# Altium schematic sheet Power.SchDoc(Power)
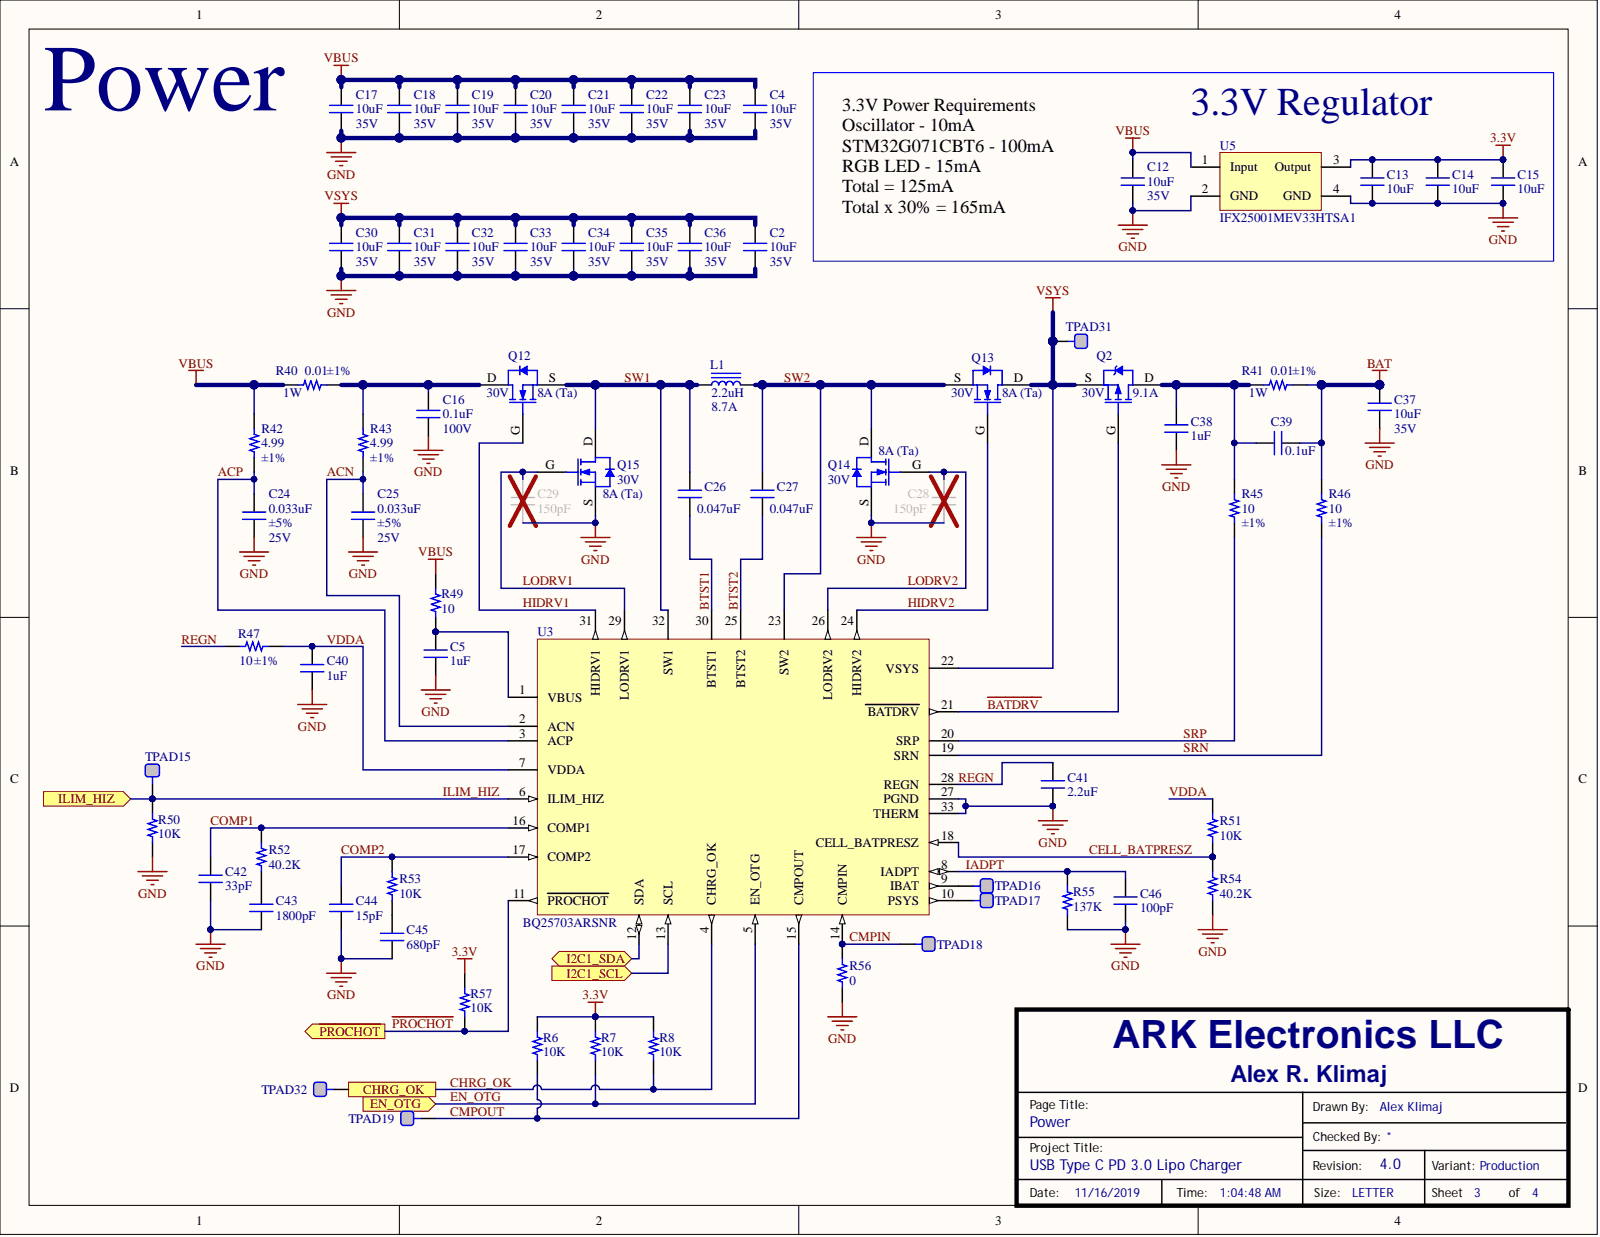
[diagram p0: Power.SchDoc]

## Parts
C2  10uF  Voltage_Rating: 35V  [CAPC0805(2012)155_N]
C4  10uF  Voltage_Rating: 35V  [CAPC0805(2012)155_N]
C5  1uF  [CAPC0805(2012)155_N]
C12  10uF  Voltage_Rating: 35V  [CAPC0805(2012)155_N]
C13  10uF  [CAPC0805(2012)155_N]
C14  10uF  [CAPC0805(2012)155_N]
C15  10uF  [CAPC0805(2012)155_N]
C16  0.1uF  Voltage_Rating: 100V  [CAPC0805(2012)155_N]
C17  10uF  Voltage_Rating: 35V  [CAPC0805(2012)155_N]
C18  10uF  Voltage_Rating: 35V  [CAPC0805(2012)155_N]
C19  10uF  Voltage_Rating: 35V  [CAPC0805(2012)155_N]
C20  10uF  Voltage_Rating: 35V  [CAPC0805(2012)155_N]
C21  10uF  Voltage_Rating: 35V  [CAPC0805(2012)155_N]
C22  10uF  Voltage_Rating: 35V  [CAPC0805(2012)155_N]
C23  10uF  Voltage_Rating: 35V  [CAPC0805(2012)155_N]
C24  0.033uF  Voltage_Rating: 25V  Tolerance: ±5%  [CAPC0805(2012)155_N]
C25  0.033uF  Voltage_Rating: 25V  Tolerance: ±5%  [CAPC0805(2012)155_N]
C26  0.047uF  [CAPC0805(2012)155_N]
C27  0.047uF  [CAPC0805(2012)155_N]
C28  150pF  [CAPC0805(2012)155_N]
C29  150pF  [CAPC0805(2012)155_N]
C30  10uF  Voltage_Rating: 35V  [CAPC0805(2012)155_N]
C31  10uF  Voltage_Rating: 35V  [CAPC0805(2012)155_N]
C32  10uF  Voltage_Rating: 35V  [CAPC0805(2012)155_N]
C33  10uF  Voltage_Rating: 35V  [CAPC0805(2012)155_N]
C34  10uF  Voltage_Rating: 35V  [CAPC0805(2012)155_N]
C35  10uF  Voltage_Rating: 35V  [CAPC0805(2012)155_N]
C36  10uF  Voltage_Rating: 35V  [CAPC0805(2012)155_N]
C37  10uF  Voltage_Rating: 35V  [CAPC0805(2012)155_N]
C38  1uF  [CAPC0805(2012)155_N]
C39  0.1uF  [CAPC0805(2012)155_N]
C40  1uF  [CAPC0805(2012)155_N]
C41  2.2uF  [CAPC0805(2012)155_N]
C42  33pF  [CAPC0805(2012)155_N]
C43  1800pF  [CAPC0805(2012)155_N]
C44  15pF  [CAPC0805(2012)155_N]
C45  680pF  [CAPC0805(2012)155_N]
C46  100pF  [CAPC0805(2012)155_N]
L1  2.2uH  Current_Rating: 8.7A  [DD1217AS-H-2R2N=P3]
Q2  Voltage_Rating: 30V  Current_Rating: 9.1A  [SOP-8 PMOS Micro Commercial]  (hidden comment: MCQ4435-TP)
Q12  Voltage_Rating: 30V  Current_Rating: 8A (Ta)  [RQ3E080BNTB]  (hidden comment: RQ3E080BNTB)
Q13  Voltage_Rating: 30V  Current_Rating: 8A (Ta)  [RQ3E080BNTB]  (hidden comment: RQ3E080BNTB)
Q14  Voltage_Rating: 30V  Current_Rating: 8A (Ta)  [RQ3E080BNTB]  (hidden comment: RQ3E080BNTB)
Q15  Voltage_Rating: 30V  Current_Rating: 8A (Ta)  [RQ3E080BNTB]  (hidden comment: RQ3E080BNTB)
R6  10K  [RESC0805(2012)_L]
R7  10K  [RESC0805(2012)_L]
R8  10K  [RESC0805(2012)_L]
R40  0.01  Power_Rating: 1W  [RES1206]
R41  0.01  Power_Rating: 1W  [RES1206]
R42  4.99  Tolerance: ±1%  [RESC0805(2012)_L]
R43  4.99  Tolerance: ±1%  [RESC0805(2012)_L]
R45  10  Tolerance: ±1%  [RESC0805(2012)_L]
R46  10  Tolerance: ±1%  [RESC0805(2012)_L]
R47  10  Tolerance: ±1%  [RESC0805(2012)_L]
R49  10  [RESC0805(2012)_L]
R50  10K  [RESC0805(2012)_L]
R51  10K  [RESC0805(2012)_L]
R52  40.2K  [RESC0805(2012)_L]
R53  10K  [RESC0805(2012)_L]
R54  40.2K  [RESC0805(2012)_L]
R55  137K  [RESC0805(2012)_L]
R56  0  [RESC0805(2012)_L]
R57  10K  [RESC0805(2012)_L]
TPAD15  TEST_PAD  [TEST_PAD]  (value not drawn)  (no BOM)
TPAD16  TEST_PAD  [TEST_PAD]  (value not drawn)  (no BOM)
TPAD17  TEST_PAD  [TEST_PAD]  (value not drawn)  (no BOM)
TPAD18  TEST_PAD  [TEST_PAD]  (value not drawn)  (no BOM)
TPAD19  TEST_PAD  [TEST_PAD]  (value not drawn)  (no BOM)
TPAD31  TEST_PAD  [TEST_POINT]  (value not drawn)  (no BOM)
TPAD32  TEST_PAD  [TEST_PAD]  (value not drawn)  (no BOM)
U3  BQ25703ARSNR  [QFN40P400X400X80_HS-33N]
U5  IFX25001MEV33HTSA1  [PG-SOT223-4 Infineon]

## Ports
CHRG_OK, EN_OTG, I2C1_SCL, I2C1_SDA, ILIM_HIZ, ~{PROCHOT}

## Nets
3.3V: C13.2 C14.2 C15.2 R6.2 R7.2 R8.2 R57.2 U5.3(Output)
BAT: C37.2 C39.1 R41.2 R46.2
GND: C2.1 C4.1 C5.1 C12.1 C13.1 C14.1 C15.1 C16.1 C17.1 C18.1 C19.1 C20.1 C21.1 C22.1 C23.1 C24.1 C25.1 C28.2 C29.2 C30.1 C31.1 C32.1 C33.1 C34.1 C35.1 C36.1 C37.1 C38.2 C40.2 C41.1 C42.1 C43.1 C44.1 C45.1 C46.1 Q14.S Q15.S R50.2 R54.1 R55.1 R56.2 U3.27(PGND) U3.33(THERM) U5.2(GND) U5.4(GND)
VBUS: C4.2 C12.2 C17.2 C18.2 C19.2 C20.2 C21.2 C22.2 C23.2 R40.1 R42.2 R49.2 U5.1(Input)
VSYS: C2.2 C30.2 C31.2 C32.2 C33.2 C34.2 C35.2 C36.2 Q2.S Q13.D TPAD31.1 U3.22(VSYS)
ACN: C25.2 R43.1 U3.2(ACN)
ACP: C24.2 R42.1 U3.3(ACP)
~{BATDRV}: Q2.G U3.21(BATDRV)
BTST1: C26.1 U3.30(BTST1)
BTST2: C27.1 U3.25(BTST2)
CELL_BATPRESZ: R51.1 R54.2 U3.18(CELL_BATPRESZ)
CHRG_OK: R8.1 TPAD32.1 U3.4(CHRG_OK) ; port CHRG_OK
CMPIN: R56.1 TPAD18.1 U3.14(CMPIN)
CMPOUT: R6.1 TPAD19.1 U3.15(CMPOUT)
COMP1: C42.2 R52.2 U3.16(COMP1)
COMP2: C44.2 R53.2 U3.17(COMP2)
EN_OTG: R7.1 U3.5(EN_OTG) ; port EN_OTG
HIDRV1: Q12.G U3.31(HIDRV1)
HIDRV2: Q13.G U3.24(HIDRV2)
I2C1_SCL: U3.13(SCL) ; port I2C1_SCL
I2C1_SDA: U3.12(SDA) ; port I2C1_SDA
IADPT: C46.2 R55.2 U3.8(IADPT)
ILIM_HIZ: R50.1 TPAD15.1 U3.6(ILIM_HIZ) ; port ILIM_HIZ
LODRV1: C29.1 Q15.G U3.29(LODRV1)
LODRV2: C28.1 Q14.G U3.26(LODRV2)
~{PROCHOT}: R57.1 U3.11(PROCHOT) ; port ~{PROCHOT}
REGN: C41.2 R47.2 U3.28(REGN)
SRN: R46.1 U3.19(SRN)
SRP: R45.1 U3.20(SRP)
SW1: C26.2 L1.1 Q12.S Q15.D U3.32(SW1)
SW2: C27.2 L1.2 Q13.S Q14.D U3.23(SW2)
VDDA: C40.1 R47.1 R51.2 U3.7(VDDA)
NetC5_2: C5.2 R49.1 U3.1(VBUS)
NetC16_2: C16.2 Q12.D R40.2 R43.2
NetC38_1: C38.1 C39.2 Q2.D R41.1 R45.2
NetC43_2: C43.2 R52.1
NetC45_2: C45.2 R53.1
NetTPAD16_1: TPAD16.1 U3.9(IBAT)
NetTPAD17_1: TPAD17.1 U3.10(PSYS)

## Library data of the parts
C2 C4 C12 C17-C23 C30-C37 = 30220: SMT Capacitor; Mfr Part Number: C2012X5R1V106M085AC; Mfr Part Description: CAP CER 10uF ±20% 35V X5R 0805 (2012 Metric); Manufacturer: TDK Corporation; Updated By: aklimaj; Tolerance: ±20%; Dielectric: X5R; Database Table Name: Parts; Value: 10uF; Updated_Date: 2018/10/19 21:37:43; Temperature Range: -55C to +85C; Supplier Part Number: 445-14419-1-ND; Supplier: Digi-Key; RoHS: Yes; Part_Type: Capacitor; Package_Case: 0805 (2012 Metric); LifeCycle: New; Library Name: Parts.DbLib; ID: 30220; Created_Date: 2018/10/14 19:21:49; ComponentLink2URL: https://www.digikey.com/product-detail/en/tdk-corporation/C2012X5R1V106M085AC/445-14419-1-ND/3956085; ComponentLink2Description: Supplier Part Details; ComponentLink1URL: https://product.tdk.com/info/en/catalog/datasheets/mlcc_commercial_general_en.pdf; ComponentLink1Description: Datasheet; Component Kind: Standard; Created By: aklimaj
C5 C38 C40 = 30222: SMT Capacitor; Mfr Part Number: CL21B105KBFNNNG; Mfr Part Description: CAP CER 1uF ±10% 50V X7R 0805 (2012 Metric); Manufacturer: Samsung Electro-Mechanics; Voltage_Rating: 50V; Updated By: aklimaj; Tolerance: ±10%; Dielectric: X7R; Database Table Name: Parts; Value: 1uF; Updated_Date: 2018/10/19 21:37:42; Temperature Range: -55C to +125C; Supplier Part Number: 1276-6470-1-ND; Supplier: Digi-Key; RoHS: Yes; Part_Type: Capacitor; Package_Case: 0805 (2012 Metric); LifeCycle: New; Library Name: Parts.DbLib; ID: 30222; Created_Date: 2018/10/14 19:30:56; ComponentLink2URL: https://www.digikey.com/product-detail/en/samsung-electro-mechanics/CL21B105KBFNNNG/1276-6470-1-ND/5958098; ComponentLink2Description: Supplier Part Details; ComponentLink1URL: http://www.samsungsem.com/kr/support/product-search/mlcc/CL21B105KBFNNNG.jsp; ComponentLink1Description: Datasheet; Component Kind: Standard; Created By: aklimaj
C13-C15 = 30220: SMT Capacitor; Mfr Part Number: C2012X5R1V106M085AC; Mfr Part Description: CAP CER 10uF ±20% 35V X5R 0805 (2012 Metric); Manufacturer: TDK Corporation; Voltage_Rating: 35V; Updated By: aklimaj; Tolerance: ±20%; Dielectric: X5R; Database Table Name: Parts; Value: 10uF; Updated_Date: 2018/10/19 21:37:43; Temperature Range: -55C to +85C; Supplier Part Number: 445-14419-1-ND; Supplier: Digi-Key; RoHS: Yes; Part_Type: Capacitor; Package_Case: 0805 (2012 Metric); LifeCycle: New; Library Name: Parts.DbLib; ID: 30220; Created_Date: 2018/10/14 19:21:49; ComponentLink2URL: https://www.digikey.com/product-detail/en/tdk-corporation/C2012X5R1V106M085AC/445-14419-1-ND/3956085; ComponentLink2Description: Supplier Part Details; ComponentLink1URL: https://product.tdk.com/info/en/catalog/datasheets/mlcc_commercial_general_en.pdf; ComponentLink1Description: Datasheet; Component Kind: Standard; Created By: aklimaj
C16 = 30221: SMT Capacitor; Mfr Part Number: CL21B104KCFNNNE; Mfr Part Description: CAP CER 0.1uF ±10% 100V X7R 0805 (2012 Metric); Manufacturer: Samsung Electro-Mechanics; Updated By: aklimaj; Tolerance: ±10%; Dielectric: X7R; Database Table Name: Parts; Value: 0.1uF; Updated_Date: 2018/10/19 21:37:43; Temperature Range: -55C to +125C; Supplier Part Number: 1276-6840-1-ND; Supplier: Digi-Key; RoHS: Yes; Part_Type: Capacitor; Package_Case: 0805 (2012 Metric); LifeCycle: New; Library Name: Parts.DbLib; ID: 30221; Created_Date: 2018/10/14 19:28:20; ComponentLink2URL: https://www.digikey.com/product-detail/en/samsung-electro-mechanics/CL21B104KCFNNNE/1276-6840-1-ND/5961699; ComponentLink2Description: Supplier Part Details; ComponentLink1URL: http://www.samsungsem.com/kr/support/product-search/mlcc/CL21B104KCFNNNE.jsp; ComponentLink1Description: Datasheet; Component Kind: Standard; Created By: aklimaj
C24 C25 = 30250: SMT Capacitor; Mfr Part Number: C0805C333J3RACTU; Mfr Part Description: CAP CER 0.033uF ±5% 25V X7R 0805 (2012 Metric); Manufacturer: KEMET; Updated By: aklimaj; Dielectric: X7R; Database Table Name: Parts; Value: 0.033uF; Updated_Date: 2018/11/04 16:40:46; Temperature Range: -55C to +125C; Supplier Part Number: 399-8069-1-ND; Supplier: Digi-Key; RoHS: Yes; Part_Type: Capacitor; Package_Case: 0805 (2012 Metric); LifeCycle: New; Library Name: Parts.DbLib; ID: 30250; Created_Date: 2018/11/04 16:40:30; ComponentLink2URL: https://www.digikey.com/product-detail/en/kemet/C0805C333J3RACTU/399-8069-1-ND/3471792; ComponentLink2Description: Supplier Part Details; ComponentLink1URL: https://api.kemet.com/component-edge/download/datasheet/C0805C333J3RACTU.pdf; ComponentLink1Description: Datasheet; Component Kind: Standard; Created By: aklimaj
C26 C27 = 30253: SMT Capacitor; Mfr Part Number: 0805B473J500CT; Mfr Part Description: CAP CER 0.047uF ±5% 50V X7R 0805 (2012 Metric); Manufacturer: Walsin Technology Corporation; Voltage_Rating: 50V; Updated By: aklimaj; Tolerance: ±5%; Dielectric: X7R; Database Table Name: Parts; Value: 0.047uF; Updated_Date: 2018/11/04 22:00:12; Temperature Range: -55C to +125C; Supplier Part Number: 1292-1583-1-ND; Supplier: Digi-Key; RoHS: Yes; Part_Type: Capacitor; Package_Case: 0805 (2012 Metric); LifeCycle: New; Library Name: Parts.DbLib; ID: 30253; Created_Date: 2018/11/04 21:59:57; ComponentLink2URL: https://www.digikey.com/product-detail/en/walsin-technology-corporation/0805B473J500CT/1292-1583-1-ND/9355587; ComponentLink2Description: Supplier Part Details; ComponentLink1URL: http://www.passivecomponent.com/indexsea/ASC_General_Purpose.pdf; ComponentLink1Description: Datasheet; Component Kind: Standard; Created By: aklimaj
C28 C29 = 30254: SMT Capacitor; Mfr Part Number: CL21B151KBANNNC; Mfr Part Description: CAP CER 150pF ±10% 50V X7R 0805 (2012 Metric); Manufacturer: Samsung Electro-Mechanics; Voltage_Rating: 50V; Updated By: aklimaj; Tolerance: ±10%; Dielectric: X7R; Database Table Name: Parts; Value: 150pF; Updated_Date: 2018/11/04 22:16:19; Temperature Range: -55C to +125C; Supplier Part Number: 1276-2457-1-ND; Supplier: Digi-Key; RoHS: Yes; Part_Type: Capacitor; Package_Case: 0805 (2012 Metric); LifeCycle: New; Library Name: Parts.DbLib; ID: 30254; Created_Date: 2018/11/04 22:15:55; ComponentLink2URL: https://www.digikey.com/product-detail/en/samsung-electro-mechanics/CL21B151KBANNNC/1276-2457-1-ND/3890543; ComponentLink2Description: Supplier Part Details; ComponentLink1URL: http://www.samsungsem.com/kr/support/product-search/mlcc/CL21B151KBANNNC.jsp; ComponentLink1Description: Datasheet; Component Kind: Standard; Created By: aklimaj
C39 = 30221: SMT Capacitor; Mfr Part Number: CL21B104KCFNNNE; Mfr Part Description: CAP CER 0.1uF ±10% 100V X7R 0805 (2012 Metric); Manufacturer: Samsung Electro-Mechanics; Voltage_Rating: 100V; Updated By: aklimaj; Tolerance: ±10%; Dielectric: X7R; Database Table Name: Parts; Value: 0.1uF; Updated_Date: 2018/10/19 21:37:43; Temperature Range: -55C to +125C; Supplier Part Number: 1276-6840-1-ND; Supplier: Digi-Key; RoHS: Yes; Part_Type: Capacitor; Package_Case: 0805 (2012 Metric); LifeCycle: New; Library Name: Parts.DbLib; ID: 30221; Created_Date: 2018/10/14 19:28:20; ComponentLink2URL: https://www.digikey.com/product-detail/en/samsung-electro-mechanics/CL21B104KCFNNNE/1276-6840-1-ND/5961699; ComponentLink2Description: Supplier Part Details; ComponentLink1URL: http://www.samsungsem.com/kr/support/product-search/mlcc/CL21B104KCFNNNE.jsp; ComponentLink1Description: Datasheet; Component Kind: Standard; Created By: aklimaj
C41 = 30257: SMT Capacitor; Mfr Part Number: CL21A225KAFNNNG; Mfr Part Description: CAP CER 2.2uF ±10% 25V X5R 0805 (2012 Metric); Manufacturer: Samsung Electro-Mechanics; Voltage_Rating: 25V; Updated By: aklimaj; Tolerance: ±10%; Dielectric: X5R; Database Table Name: Parts; Value: 2.2uF; Updated_Date: 2018/11/07 15:12:41; Temperature Range: -55C to +85C; Supplier Part Number: 1276-6458-1-ND; Supplier: Digi-Key; RoHS: Yes; Part_Type: Capacitor; Package_Case: 0805 (2012 Metric); LifeCycle: New; Library Name: Parts.DbLib; ID: 30257; Created_Date: 2018/11/07 15:12:27; ComponentLink2URL: https://www.digikey.com/product-detail/en/samsung-electro-mechanics/CL21A225KAFNNNG/1276-6458-1-ND/5958086; ComponentLink2Description: Supplier Part Details; ComponentLink1URL: http://www.samsungsem.com/kr/support/product-search/mlcc/CL21A225KAFNNNG.jsp; ComponentLink1Description: Datasheet; Component Kind: Standard; Created By: aklimaj
C42 = 30258: SMT Capacitor; Mfr Part Number: CL21C330JBANNNC; Mfr Part Description: CAP CER 33pF ±5% 50V NPO 0805 (2012 Metric); Manufacturer: Samsung Electro-Mechanics; Voltage_Rating: 50V; Updated By: aklimaj; Tolerance: ±5%; Dielectric: NPO; Database Table Name: Parts; Value: 33pF; Updated_Date: 2018/11/07 18:07:45; Temperature Range: -55C to +125C; Supplier Part Number: 1276-1105-1-ND; Supplier: Digi-Key; RoHS: Yes; Part_Type: Capacitor; Package_Case: 0805 (2012 Metric); LifeCycle: New; Library Name: Parts.DbLib; ID: 30258; Created_Date: 2018/11/07 18:07:31; ComponentLink2URL: https://www.digikey.com/product-detail/en/samsung-electro-mechanics/CL21C330JBANNNC/1276-1105-1-ND/3889191; ComponentLink2Description: Supplier Part Details; ComponentLink1URL: http://www.samsungsem.com/kr/support/product-search/mlcc/CL21C330JBANNNC.jsp; ComponentLink1Description: Datasheet; Component Kind: Standard; Created By: aklimaj
C43 = 30259: SMT Capacitor; Mfr Part Number: CL21B182KBANNNC; Mfr Part Description: CAP CER 1800pF ±10% 50V X7R 0805 (2012 Metric); Manufacturer: Samsung Electro-Mechanics; Voltage_Rating: 50V; Updated By: aklimaj; Tolerance: ±10%; Dielectric: X7R; Database Table Name: Parts; Value: 1800pF; Updated_Date: 2018/11/07 18:09:28; Temperature Range: -55C to +125C; Supplier Part Number: 1276-2465-1-ND; Supplier: Digi-Key; RoHS: Yes; Part_Type: Capacitor; Package_Case: 0805 (2012 Metric); LifeCycle: New; Library Name: Parts.DbLib; ID: 30259; Created_Date: 2018/11/07 18:09:13; ComponentLink2URL: https://www.digikey.com/product-detail/en/samsung-electro-mechanics/CL21B182KBANNNC/1276-2465-1-ND/3890551; ComponentLink2Description: Supplier Part Details; ComponentLink1URL: http://www.samsungsem.com/kr/support/product-search/mlcc/CL21B182KBANNNC.jsp; ComponentLink1Description: Datasheet; Component Kind: Standard; Created By: aklimaj
C44 = 30262: SMT Capacitor; Mfr Part Number: 885012007029; Mfr Part Description: CAP CER 15pF ±5% 25V NPO 0805 (2012 Metric); Manufacturer: Wurth Electronics Inc.; Voltage_Rating: 25V; Updated By: aklimaj; Tolerance: ±5%; Dielectric: NPO; Database Table Name: Parts; Value: 15pF; Updated_Date: 2018/11/07 18:23:10; Temperature Range: -55C to +125C; Supplier Part Number: 732-7828-1-ND; Supplier: Digi-Key; RoHS: Yes; Part_Type: Capacitor; Package_Case: 0805 (2012 Metric); LifeCycle: New; Library Name: Parts.DbLib; ID: 30262; Created_Date: 2018/11/07 18:22:56; ComponentLink2URL: https://www.digikey.com/product-detail/en/wurth-electronics-inc/885012007029/732-7828-1-ND/5454455; ComponentLink2Description: Supplier Part Details; ComponentLink1URL: https://katalog.we-online.de/pbs/datasheet/885012007029.pdf; ComponentLink1Description: Datasheet; Component Kind: Standard; Created By: aklimaj
C45 = 30261: SMT Capacitor; Mfr Part Number: CL21B681KBANNNC; Mfr Part Description: CAP CER 680pF ±10% 50V X7R 0805 (2012 Metric); Manufacturer: Samsung Electro-Mechanics; Voltage_Rating: 50V; Updated By: aklimaj; Tolerance: ±10%; Dielectric: X7R; Database Table Name: Parts; Value: 680pF; Updated_Date: 2018/11/07 18:21:25; Temperature Range: -55C to +125C; Supplier Part Number: 1276-2538-1-ND; Supplier: Digi-Key; RoHS: Yes; Part_Type: Capacitor; Package_Case: 0805 (2012 Metric); LifeCycle: New; Library Name: Parts.DbLib; ID: 30261; Created_Date: 2018/11/07 18:21:13; ComponentLink2URL: https://www.digikey.com/product-detail/en/samsung-electro-mechanics/CL21B681KBANNNC/1276-2538-1-ND/3890624; ComponentLink2Description: Supplier Part Details; ComponentLink1URL: http://www.samsungsem.com/kr/support/product-search/mlcc/CL21B681KBANNNC.jsp; ComponentLink1Description: Datasheet; Component Kind: Standard; Created By: aklimaj
C46 = 30264: SMT Capacitor; Mfr Part Number: CL21C101JC61PNC; Mfr Part Description: CAP CER 100pF ±5% 100V NPO 0805 (2012 Metric); Manufacturer: Samsung Electro-Mechanics; Voltage_Rating: 100V; Updated By: aklimaj; Tolerance: ±5%; Dielectric: NPO; Database Table Name: Parts; Value: 100pF; Updated_Date: 2018/11/07 18:38:44; Temperature Range: -55C to +125C; Supplier Part Number: 1276-2569-1-ND; Supplier: Digi-Key; RoHS: Yes; Part_Type: Capacitor; Package_Case: 0805 (2012 Metric); LifeCycle: New; Library Name: Parts.DbLib; ID: 30264; Created_Date: 2018/11/07 18:38:28; ComponentLink2URL: https://www.digikey.com/product-detail/en/samsung-electro-mechanics/CL21C101JC61PNC/1276-2569-1-ND/3890655; ComponentLink2Description: Supplier Part Details; ComponentLink1URL: http://www.samsungsem.com/kr/support/product-search/mlcc/CL21C101JC61PNC.jsp; ComponentLink1Description: Datasheet; Component Kind: Standard; Created By: aklimaj
L1 = 30252: SMT Inductor; Mfr Part Description: INDUCTOR 2.2uH ±30% 8.7A Nonstandard; Manufacturer: Murata Electronics North America; Updated By: aklimaj; Tolerance: ±30%; Database Table Name: Parts; Value: 2.2uH; Updated_Date: 2018/11/04 21:56:42; Supplier Part Number: 490-13196-1-ND; Supplier: Digi-Key; RoHS: Yes; Part_Type: Inductor; Package_Case: Nonstandard; Mfr Part Number: DD1217AS-H-2R2N=P3; LifeCycle: New; Library Name: Parts.DbLib; ID: 30252; Created_Date: 2018/11/04 21:43:14; ComponentLink2URL: https://www.digikey.com/product-detail/en/murata-electronics-north-america/DD1217AS-H-2R2N=P3/490-13196-1-ND/5812908; ComponentLink2Description: Supplier Part Details; ComponentLink1URL: http://search.murata.co.jp/Ceramy/image/img/P02/J(E)TE243B-0036_DEM8045C(DD)_reference.pdf; ComponentLink1Description: Datasheet; Component Kind: Standard; Created By: aklimaj
Q2 = 30255: Part_Type: Discrete; Mfr Part Description: P-CHANNEL MOSFET, SOP-8 PACKAGE; Manufacturer: Micro Commercial Co; Updated By: aklimaj; Database Table Name: Parts; Value: MCQ4435-TP; Updated_Date: 2018/11/06 10:10:44; Temperature Range: -55C to +150C (TJ); Supplier Part Number: MCQ4435-TPMSCT-ND; Supplier: Digi-Key; RoHS: Yes; Package_Case: 8-SOIC (0.154", 3.90mm Width); Mfr Part Number: MCQ4435-TP; LifeCycle: New; Library Name: Parts.DbLib; ID: 30255; Created_Date: 2018/11/05 12:00:21; ComponentLink2URL: https://www.digikey.com/product-detail/en/micro-commercial-co/MCQ4435-TP/MCQ4435-TPMSCT-ND/6616138; ComponentLink2Description: Supplier Part Details; ComponentLink1URL: http://www.mccsemi.com/up_pdf/MCQ4435(SOP-8)-B.pdf; ComponentLink1Description: Datasheet; Component Kind: Standard; Created By: aklimaj
Q12-Q15 = 30251: Part_Type: Discrete; Mfr Part Description: MOSFET N-CH 30V 8A HSMT8; Manufacturer: Rohm Semiconductor; Updated By: aklimaj; Database Table Name: Parts; Value: RQ3E080BNTB; Updated_Date: 2018/11/04 17:31:45; Temperature Range: 150C (TJ); Supplier Part Number: RQ3E080BNTBCT-ND; Supplier: Digi-Key; RoHS: Yes; Package_Case: 8-PowerVDFN; Mfr Part Number: RQ3E080BNTB; LifeCycle: New; Library Name: Parts.DbLib; ID: 30251; Created_Date: 2018/11/04 17:08:16; ComponentLink2URL: https://www.digikey.com/product-detail/en/rohm-semiconductor/RQ3E080BNTB/RQ3E080BNTBCT-ND/5042543; ComponentLink2Description: Supplier Part Details; ComponentLink1URL: https://www.rohm.com/datasheet/RQ3E080BN/rq3e080bn-e; ComponentLink1Description: Datasheet; Component Kind: Standard; Created By: aklimaj
R6-R8 R50 R51 R53 R57 = 30227: SMT Resistor; Mfr Part Number: CR0805-FX-1002ELF; Mfr Part Description: RES 10K Ohm ±1% 1/8W 0805 (2012 Metric); Manufacturer: Bourns Inc.; Updated By: aklimaj; Tolerance: ±1%; Temperature Coeff: ±100ppm/degC; Power_Rating: 0.125W, 1/8W; Database Table Name: Parts; Value: 10K; Updated_Date: 2018/10/19 21:37:37; Temperature Range: -55C to +155C; Supplier Part Number: CR0805-FX-1002ELFCT-ND; Supplier: Digi-Key; RoHS: Yes; Part_Type: Resistor; Package_Case: 0805 (2012 Metric); LifeCycle: New; Library Name: Parts.DbLib; ID: 30227; Created_Date: 2018/10/16 22:16:46; ComponentLink2URL: https://www.digikey.com/product-detail/en/bourns-inc/CR0805-FX-1002ELF/CR0805-FX-1002ELFCT-ND/3592928; ComponentLink2Description: Supplier Part Details; ComponentLink1URL: https://www.bourns.com/docs/Product-Datasheets/CHPREZTR.pdf; ComponentLink1Description: Datasheet; Component Kind: Standard; Created By: aklimaj
R40 R41 = 30248: SMT Resistor; Mfr Part Number: LVT12R0100FER; Mfr Part Description: RES 0.01 Ohm ±1% 1W 1206 (3216 Metric); Manufacturer: Ohmite; Updated By: aklimaj; Tolerance: ±1%; Temperature Coeff: ±75ppm/degC; Database Table Name: Parts; Value: 0.01; Updated_Date: 2018/11/07 17:03:59; Temperature Range: -55C to +155C; Supplier Part Number: LVT12R0100FERCT-ND; Supplier: Digi-Key; RoHS: Yes; Part_Type: Resistor; Package_Case: 1206 (3216 Metric); LifeCycle: New; Library Name: Parts.DbLib; ID: 30248; Created_Date: 2018/11/04 16:28:38; ComponentLink2URL: https://www.digikey.com/product-detail/en/ohmite/LVT12R0100FER/LVT12R0100FERCT-ND/9556182; ComponentLink2Description: Supplier Part Details; ComponentLink1URL: https://www.ohmite.com/assets/docs/res_lvt.pdf?r=false; ComponentLink1Description: Datasheet; Component Kind: Standard; Created By: aklimaj
R42 R43 = 30249: SMT Resistor; Mfr Part Number: RMCF0805FT4R99; Mfr Part Description: RES 4.99 Ohm ±1% 1/8W 0805 (2012 Metric); Manufacturer: Stackpole Electronics Inc.; Updated By: aklimaj; Temperature Coeff: ±200ppm/degC; Power_Rating: 0.125W, 1/8W; Database Table Name: Parts; Value: 4.99; Updated_Date: 2018/11/04 16:35:46; Temperature Range: -55C to +155C; Supplier Part Number: RMCF0805FT4R99CT-ND; Supplier: Digi-Key; RoHS: Yes; Part_Type: Resistor; Package_Case: 0805 (2012 Metric); LifeCycle: New; Library Name: Parts.DbLib; ID: 30249; Created_Date: 2018/11/04 16:35:28; ComponentLink2URL: https://www.digikey.com/product-detail/en/stackpole-electronics-inc/RMCF0805FT4R99/RMCF0805FT4R99CT-ND/2418423; ComponentLink2Description: Supplier Part Details; ComponentLink1URL: https://www.seielect.com/Catalog/SEI-RMCF_RMCP.pdf; ComponentLink1Description: Datasheet; Component Kind: Standard; Created By: aklimaj
R45-R47 = 30256: SMT Resistor; Mfr Part Number: RMCF0805FT10R0; Mfr Part Description: RES 10 Ohm ±1% 1/8W 0805 (2012 Metric); Manufacturer: Stackpole Electronics Inc.; Updated By: aklimaj; Temperature Coeff: ±100ppm/degC; Power_Rating: 0.125W, 1/8W; Database Table Name: Parts; Value: 10; Updated_Date: 2018/11/07 14:51:54; Temperature Range: -55C to +155C; Supplier Part Number: RMCF0805FT10R0CT-ND; Supplier: Digi-Key; RoHS: Yes; Part_Type: Resistor; Package_Case: 0805 (2012 Metric); LifeCycle: New; Library Name: Parts.DbLib; ID: 30256; Created_Date: 2018/11/07 14:51:36; ComponentLink2URL: https://www.digikey.com/product-detail/en/stackpole-electronics-inc/RMCF0805FT10R0/RMCF0805FT10R0CT-ND/1942314; ComponentLink2Description: Supplier Part Details; ComponentLink1URL: https://www.seielect.com/Catalog/SEI-RMCF_RMCP.pdf; ComponentLink1Description: Datasheet; Component Kind: Standard; Created By: aklimaj
R49 = 30256: SMT Resistor; Mfr Part Number: RMCF0805FT10R0; Mfr Part Description: RES 10 Ohm ±1% 1/8W 0805 (2012 Metric); Manufacturer: Stackpole Electronics Inc.; Updated By: aklimaj; Tolerance: ±1%; Temperature Coeff: ±100ppm/degC; Power_Rating: 0.125W, 1/8W; Database Table Name: Parts; Value: 10; Updated_Date: 2018/11/07 14:51:54; Temperature Range: -55C to +155C; Supplier Part Number: RMCF0805FT10R0CT-ND; Supplier: Digi-Key; RoHS: Yes; Part_Type: Resistor; Package_Case: 0805 (2012 Metric); LifeCycle: New; Library Name: Parts.DbLib; ID: 30256; Created_Date: 2018/11/07 14:51:36; ComponentLink2URL: https://www.digikey.com/product-detail/en/stackpole-electronics-inc/RMCF0805FT10R0/RMCF0805FT10R0CT-ND/1942314; ComponentLink2Description: Supplier Part Details; ComponentLink1URL: https://www.seielect.com/Catalog/SEI-RMCF_RMCP.pdf; ComponentLink1Description: Datasheet; Component Kind: Standard; Created By: aklimaj
R52 R54 = 30260: SMT Resistor; Mfr Part Number: RC0805FR-0740K2L; Mfr Part Description: RES 40.2K Ohm ±1% 1/8W 0805 (2012 Metric); Manufacturer: Yageo; Updated By: aklimaj; Tolerance: ±1%; Temperature Coeff: ±100ppm/degC; Power_Rating: 0.125W, 1/8W; Database Table Name: Parts; Value: 40.2K; Updated_Date: 2018/11/07 18:10:59; Temperature Range: -55C to +155C; Supplier Part Number: 311-40.2KCRCT-ND; Supplier: Digi-Key; RoHS: Yes; Part_Type: Resistor; Package_Case: 0805 (2012 Metric); LifeCycle: New; Library Name: Parts.DbLib; ID: 30260; Created_Date: 2018/11/07 18:10:45; ComponentLink2URL: https://www.digikey.com/product-detail/en/yageo/RC0805FR-0740K2L/311-40.2KCRCT-ND/730888; ComponentLink2Description: Supplier Part Details; ComponentLink1URL: http://www.yageo.com/documents/recent/PYu-RC_Group_51_RoHS_L_9.pdf; ComponentLink1Description: Datasheet; Component Kind: Standard; Created By: aklimaj
R55 = 30263: SMT Resistor; Mfr Part Number: RMCF0805FT137K; Mfr Part Description: RES 137K Ohm ±1% 1/8W 0805 (2012 Metric); Manufacturer: Stackpole Electronics Inc.; Updated By: aklimaj; Tolerance: ±1%; Temperature Coeff: ±100ppm/degC; Power_Rating: 0.125W, 1/8W; Database Table Name: Parts; Value: 137K; Updated_Date: 2018/11/07 18:36:20; Temperature Range: -55C to +155C; Supplier Part Number: RMCF0805FT137KCT-ND; Supplier: Digi-Key; RoHS: Yes; Part_Type: Resistor; Package_Case: 0805 (2012 Metric); LifeCycle: New; Library Name: Parts.DbLib; ID: 30263; Created_Date: 2018/11/07 18:36:04; ComponentLink2URL: https://www.digikey.com/product-detail/en/stackpole-electronics-inc/RMCF0805FT137K/RMCF0805FT137KCT-ND/2418254; ComponentLink2Description: Supplier Part Details; ComponentLink1URL: https://www.seielect.com/Catalog/SEI-RMCF_RMCP.pdf; ComponentLink1Description: Datasheet; Component Kind: Standard; Created By: aklimaj
R56 = 30238: SMT Resistor; Mfr Part Number: CR0805-J/-000ELF; Mfr Part Description: RES 0 Ohm Jumper 1/8W 0805 (2012 Metric); Manufacturer: Bourns Inc.; Voltage_Rating: 150V; Updated By: aklimaj; Tolerance: Jumper; Power_Rating: 0.125W, 1/8W; Database Table Name: Parts; Current_Rating: 2A; Value: 0; Updated_Date: 2018/11/02 22:20:59; Temperature Range: -55C to +155C; Supplier Part Number: CR0805-J/-000ELFCT-ND; Supplier: Digi-Key; RoHS: Yes; Part_Type: Resistor; Package_Case: 0805 (2012 Metric); LifeCycle: New; Library Name: Parts.DbLib; ID: 30238; Created_Date: 2018/11/02 22:19:43; ComponentLink2URL: https://www.digikey.com/product-detail/en/bourns-inc/CR0805-J-000ELF/CR0805-J-000ELFCT-ND/3592913; ComponentLink2Description: Supplier Part Details; ComponentLink1URL: https://www.bourns.com/docs/Product-Datasheets/CHPREZTR.pdf; ComponentLink1Description: Datasheet; Component Kind: Standard; Created By: aklimaj
TPAD15-TPAD19 TPAD31 TPAD32 = 30015: Part_Type: Test Point; Updated By: aklimaj; Database Table Name: Parts; Updated_Date: 2017/07/13 09:29:11; RoHS: Yes; Mfr Part Description: Test Point; LifeCycle: New; Library Name: Parts.DbLib; ID: 30015; Description: TEST_PAD; Created_Date: 2017/07/13 09:26:35; ComponentLink2Description: Supplier Part Details; ComponentLink1Description: Datasheet; Created By: aklimaj
U3 = 30225: Part_Type: IC; Manufacturer: Texas Instruments; Updated By: aklimaj; Sub_Type: Power; Database Table Name: Parts; Value: BQ25703ARSNR; Updated_Date: 2018/10/19 21:37:40; Temperature Range: -40C to +85C (TA); Supplier Part Number: 296-47649-1-ND; Supplier: Digi-Key; RoHS: Yes; Package_Case: 32-WFQFN Exposed Pad; Mfr Part Number: BQ25703ARSNR; Mfr Part Description: BQ25703ARSNR; LifeCycle: New; Library Name: Parts.DbLib; ID: 30225; Created_Date: 2018/10/14 22:39:52; ComponentLink2URL: https://www.digikey.com/product-detail/en/texas-instruments/BQ25703ARSNR/296-47649-1-ND/8133018; ComponentLink2Description: Supplier Part Details; ComponentLink1URL: http://www.ti.com/lit/ds/symlink/bq25703a.pdf; ComponentLink1Description: Datasheet; Component Kind: Standard; Created By: aklimaj
U5 = 30240: Part_Type: IC; Mfr Part Description: IC REG LIN 3.3V 400MA SOT223-4; Manufacturer: Infineon Technologies; Updated By: aklimaj; Sub_Type: REG; Database Table Name: Parts; Value: IFX25001MEV33HTSA1; Updated_Date: 2018/11/03 12:39:32; Temperature Range: -40C to +125C; Supplier Part Number: IFX25001MEV33HTSA1CT-ND; Supplier: Digi-Key; RoHS: Yes; Package_Case: TO-261-4, TO-261AA; Mfr Part Number: IFX25001MEV33HTSA1; LifeCycle: New; Library Name: Parts.DbLib; ID: 30240; Created_Date: 2018/11/03 12:30:17; ComponentLink2URL: https://www.digikey.com/product-detail/en/infineon-technologies/IFX25001MEV33HTSA1/IFX25001MEV33HTSA1CT-ND/2270385; ComponentLink2Description: Supplier Part Details; ComponentLink1URL: https://media.digikey.com/pdf/Data%20Sheets/Infineon%20PDFs/IFX25001.pdf; ComponentLink1Description: Datasheet; Component Kind: Standard; Created By: aklimaj
